# Altium schematic sheet power.SchDoc
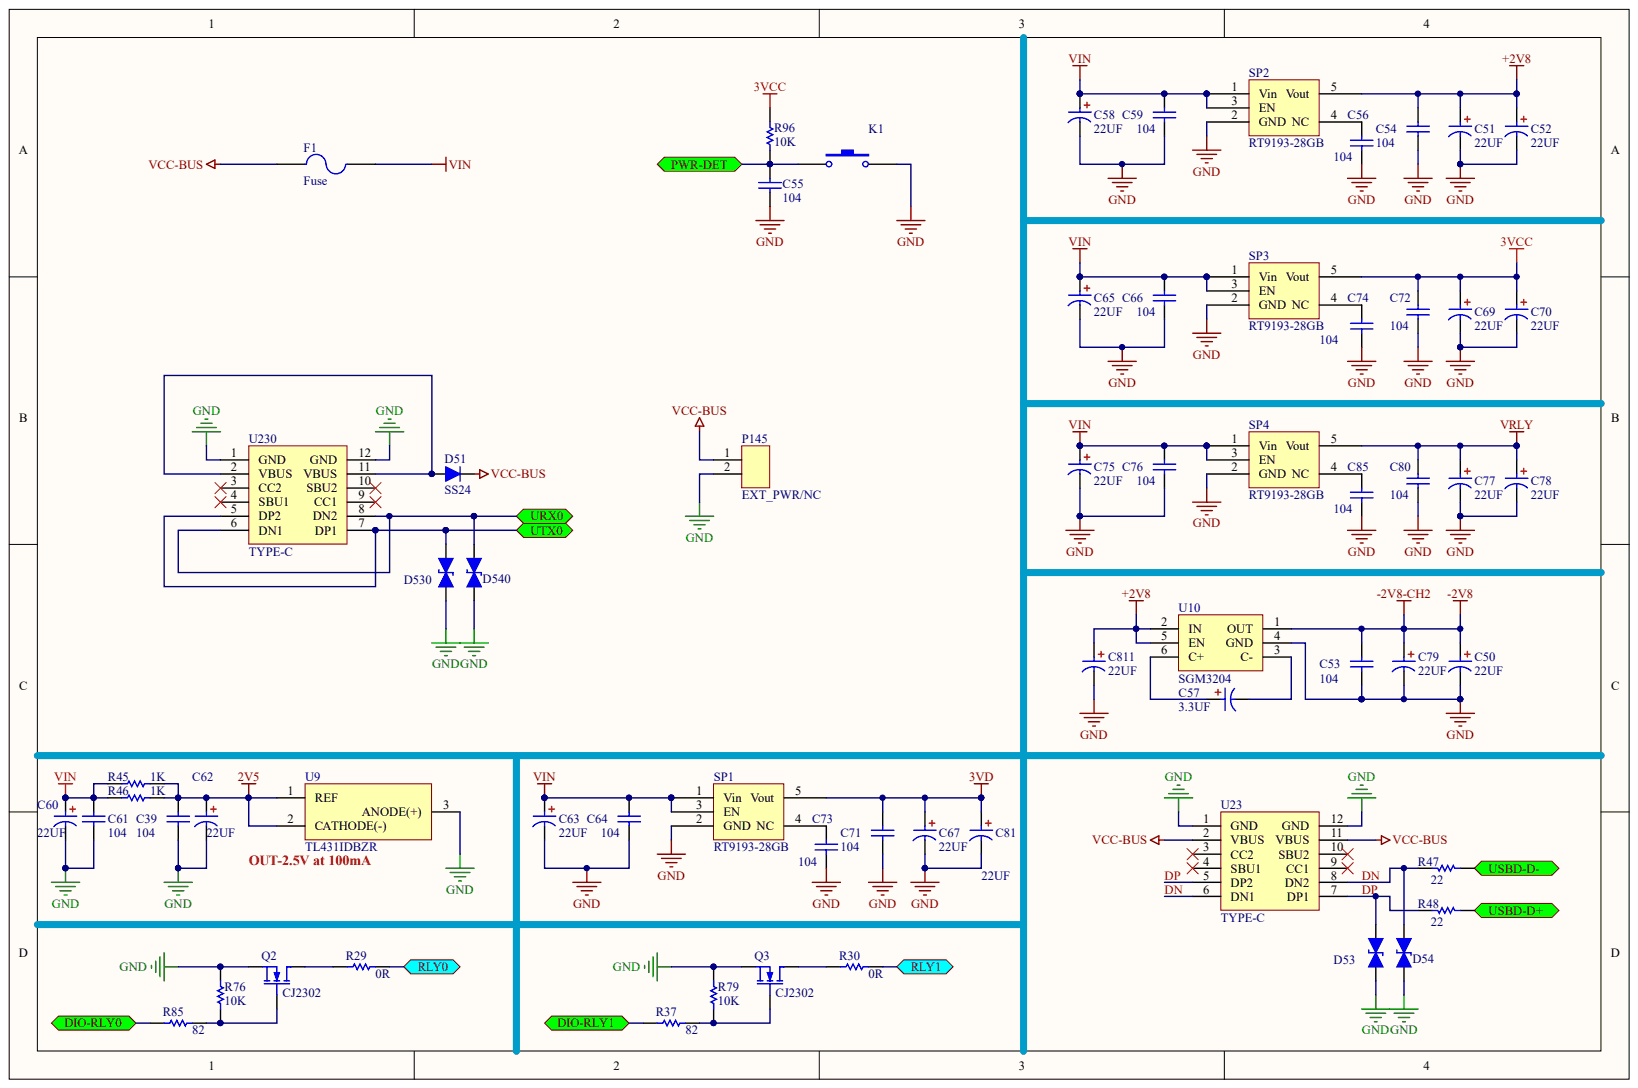
[diagram p0: power.SchDoc]

## Parts
C39  C104  (value not drawn)
C50  22UF
C51  22UF
C52  22UF
C53  C104  (value not drawn)
C54  C104  (value not drawn)
C55  C104  (value not drawn)
C56  C104  (value not drawn)
C57  3.3UF
C58  22UF
C59  C104  (value not drawn)
C60  22UF
C61  C104  (value not drawn)
C62  22UF
C63  22UF
C64  C104  (value not drawn)
C65  22UF
C66  C104  (value not drawn)
C67  22UF
C69  22UF
C70  22UF
C71  C104  (value not drawn)
C72  C104  (value not drawn)
C73  C104  (value not drawn)
C74  C104  (value not drawn)
C75  22UF
C76  C104  (value not drawn)
C77  22UF
C78  22UF
C79  22UF
C80  C104  (value not drawn)
C81  22UF
C85  C104  (value not drawn)
C811  22UF
D51  SS24
D53  ESD_0402  (value not drawn)
D54  ESD_0402  (value not drawn)
D530  ESD_0402  (value not drawn)
D540  ESD_0402  (value not drawn)
F1  Fuse
K1  KEY-PB  (value not drawn)
P145  EXT_PWR/NC
Q2  CJ2302
Q3  CJ2302
R29  0R
R30  0R
R37  82
R45  1K
R46  1K
R47  22
R48  22
R76  10K
R79  10K
R85  82
R96  10K
SP1  RT9193-28GB
SP2  RT9193-28GB
SP3  RT9193-28GB
SP4  RT9193-28GB
U9  TL431IDBZR
U10  SGM3204
U23  TYPE-C
U230  TYPE-C

## Ports
DIO-RLY0, DIO-RLY1, PWR-DET, RLY0, RLY1, URX0, USBD-D+, USBD-D-, UTX0

## Nets
2V5: C39.1 C62.1 R45.1 R46.2 U9.1 U9.2
3VCC: C69.1 C70.1 C72.1 R96.1 SP3.5(Vout)
3VD: C67.1 C71.1 C81.1 SP1.5(Vout)
+2V8: C51.1 C52.1 C54.1 C811.1 SP2.5(Vout) U10.2(IN) U10.5(EN)
-2V8: C50.1 C53.1 C79.1 U10.1(OUT)
GND: C39.2 C50.2 C51.2 C52.2 C53.2 C54.2 C55.1 C56.2 C58.2 C59.2 C60.2 C61.2 C62.2 C63.2 C64.2 C65.2 C66.2 C67.2 C69.2 C70.2 C71.2 C72.2 C73.2 C74.2 C75.2 C76.2 C77.2 C78.2 C79.2 C80.2 C81.2 C85.2 C811.2 D53.2 D54.2 D530.2 D540.2 K1.2 P145.2 Q2.2 Q3.2 R76.1 R79.1 SP1.2(GND) SP2.2(GND) SP3.2(GND) SP4.2(GND) U9.3 U10.4(GND) U23.1(GND) U23.12(GND) U230.1(GND) U230.12(GND)
VCC-BUS: D51.2 F1.1 P145.1 U23.2(VBUS) U23.11(VBUS)
VIN: C58.1 C59.1 C60.1 C61.1 C63.1 C64.1 C65.1 C66.1 C75.1 C76.1 F1.2 R45.2 R46.1 SP1.1(Vin) SP1.3(EN) SP2.1(Vin) SP2.3(EN) SP3.1(Vin) SP3.3(EN) SP4.1(Vin) SP4.3(EN)
VRLY: C77.1 C78.1 C80.1 SP4.5(Vout)
DIO-RLY0: R85.1 ; port DIO-RLY0
DIO-RLY1: R37.1 ; port DIO-RLY1
DN: D54.1 R47.2 U23.6(DN1) U23.8(DN2)
DP: D53.1 R48.2 U23.5(DP2) U23.7(DP1)
PWR-DET: C55.2 K1.1 R96.2 ; port PWR-DET
RLY0: R29.2 ; port RLY0
RLY1: R30.2 ; port RLY1
URX0: D540.1 U230.6(DN1) U230.8(DN2) ; port URX0
UTX0: D530.1 U230.5(DP2) U230.7(DP1) ; port UTX0
NetC56_1: C56.1 SP2.4(NC)
NetC57_1: C57.1 U10.6(C+)
NetC57_2: C57.2 U10.3(C-)
NetC73_1: C73.1 SP1.4(NC)
NetC74_1: C74.1 SP3.4(NC)
NetC85_1: C85.1 SP4.4(NC)
NetD51_1: D51.1 U230.2(VBUS) U230.11(VBUS)
NetQ2_1: Q2.1 R76.2 R85.2
NetQ2_3: Q2.3 R29.1
NetQ3_1: Q3.1 R37.2 R79.2
NetQ3_3: Q3.3 R30.1
NetR47_1: R47.1
NetR48_1: R48.1

Not connected: U23 3(CC2) 4(SBU1) 9(CC1) 10(SBU2); U230 3(CC2) 4(SBU1) 9(CC1) 10(SBU2)

## Library data of the parts
C39 C50-C67 C69-C81 C85 C811 D51 D53 D54 D530 D540 F1 K1 P145 Q2 Q3 R29 R30 R37 R45-R48 R76 R79 R85 R96 SP1-SP4 U9 U10 U23 U230 = (no symbol name)
  C39 C53-C56 C59 C61 C64 C66 C71-C74 C76 C80 C85: : 104
  C50-C52 C58 C60 C62 C63 C65 C67 C69 C70 C75 C77-C79 C81 C811: : 22UF
  C57: : 3.3UF
  F1: Published: 8-Jun-2000; LatestRevisionDate: 17-Jul-2002; LatestRevisionNote: Re-released for DXP Platform.; PackageReference: PIN-W2/E2.8; PackageDescription: Thru-Hole; 2 Leads
  R29 R30: : 0R
  R37 R85: : 82
  R45: Published: 8-Jun-2000; LatestRevisionDate: 17-Jul-2002; LatestRevisionNote: Re-released for DXP Platform.; PackageReference: AXIAL-0.3; Value: 1K
  R46: : 1K
  R47 R48: : 22
  R76 R79 R96: : 10K
  F1 R45: Publisher: Altium Limited
